# Altium schematic sheet CASSA-CPU.SchDoc(CASSA-CPU)
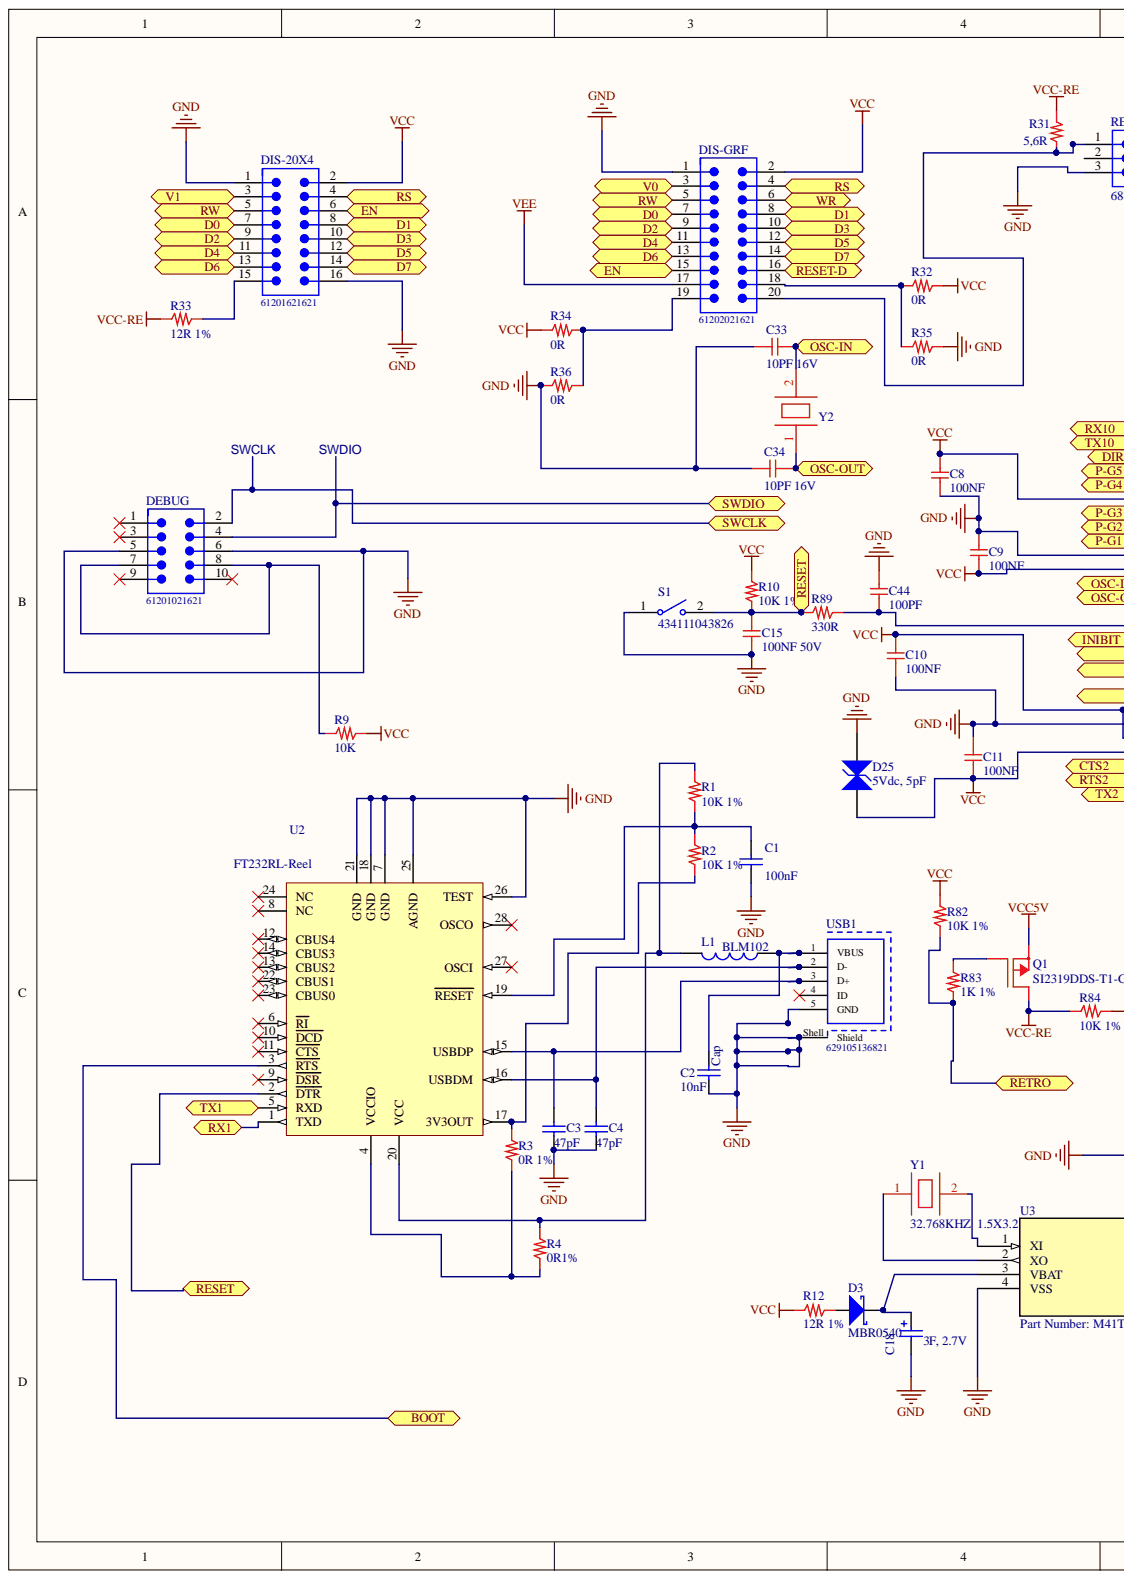
[diagram p0: CASSA-CPU.SchDoc, left]
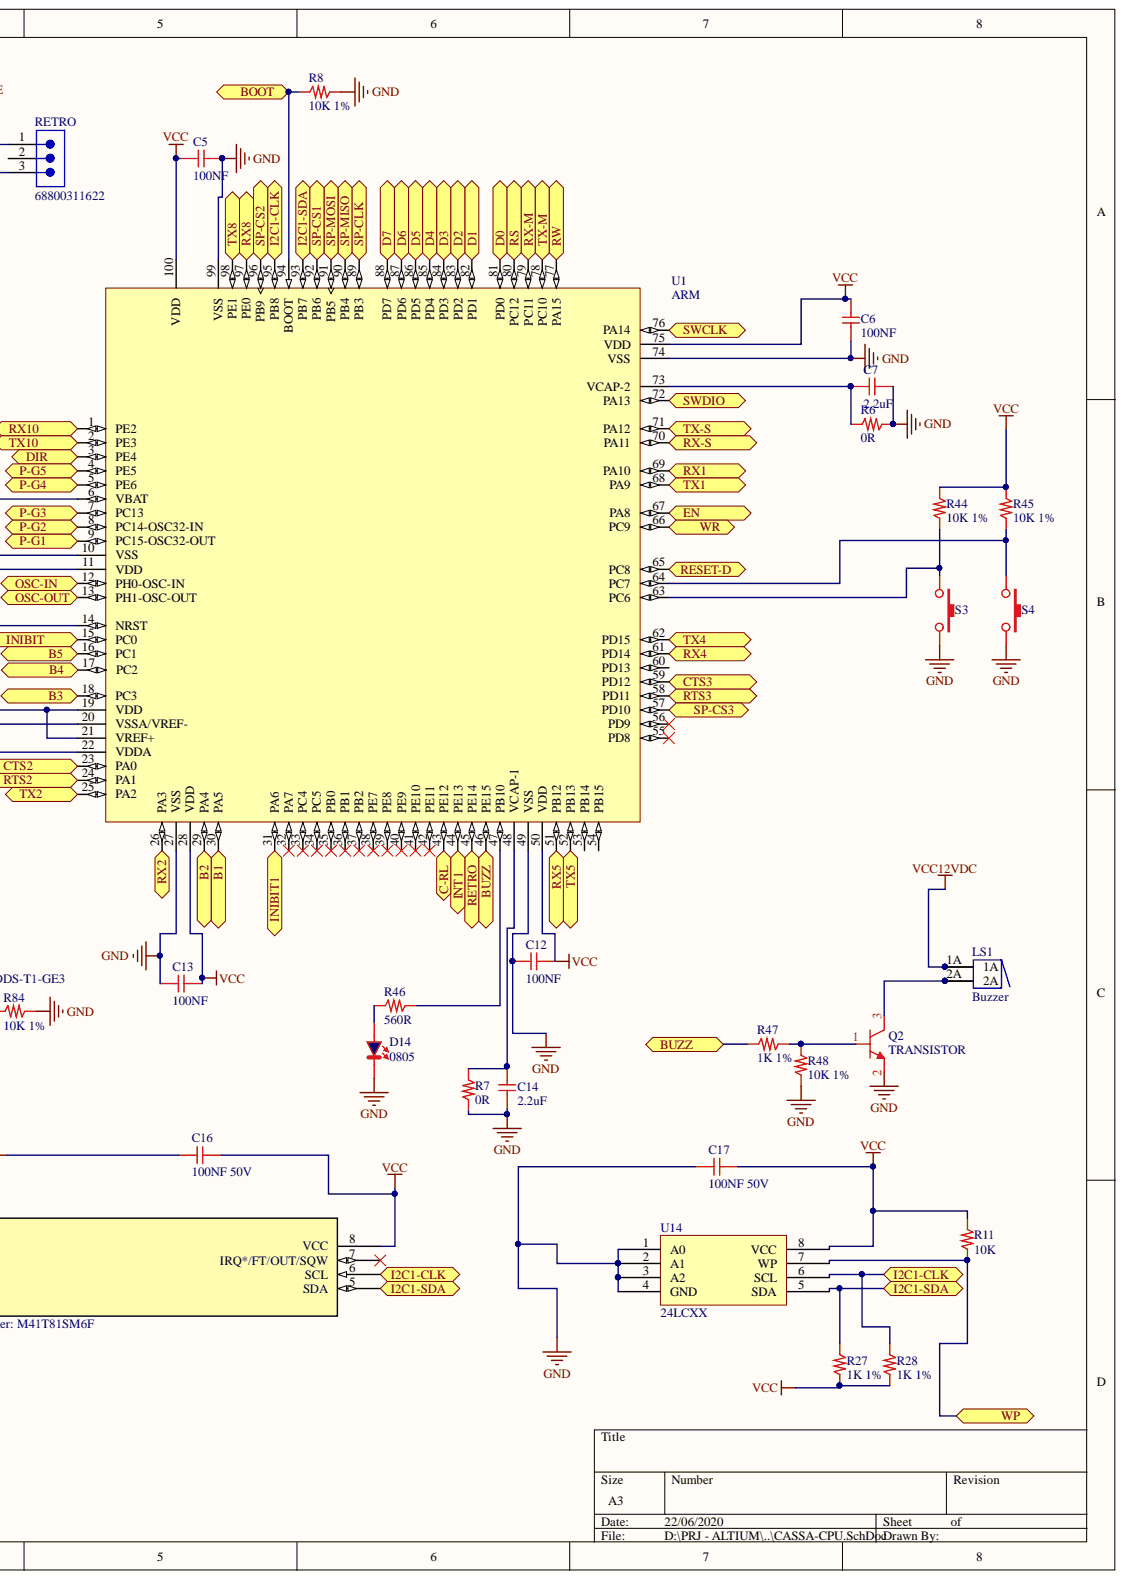
[diagram p1: CASSA-CPU.SchDoc, right]

## Parts
C1  value 100nF  [0603S_C]
C2  Cap  value 10nF  [0603S_C]
C3  value 47pF  [0603S_C]
C4  value 47pF  [0603S_C]
C5  100NF  [0402_C]
C6  100NF  [0402_C]
C7  2.2uF  [0603_c]
C8  100NF  [0402_C]
C9  100NF  [0402_C]
C10  100NF  [0402_C]
C11  100NF  [0402_C]
C12  100NF  [0402_C]
C13  100NF  [0402_C]
C14  2.2uF  [0603_c]
C15  100NF 50V  [0603_c]
C16  100NF 50V  [0603_c]
C17  100NF 50V  [0603_c]
C18  3F, 2.7V  [WCAP-STSC_8x20x3.5x0.6]
C33  10PF 16V  [0603_c]
C34  10PF 16V  [0603_c]
C44  100PF  [0402_C]
D3  MBR0540  [SOD123]
D14  0805  [0805-BLU]
D25  5Vdc, 5pF  [WE-VE_0603]
DEBUG  61201021621  [61201021621]
DIS-20X4  61201621621  [61201621621]
DIS-GRF  61202021621  [61202021621]
L1  BLM102  [WE-CBF_0805_Wide Band]
LS1  Buzzer  [BUZZER-14mm]
Q1  SI2319DDS-T1-GE3  [SOT23]
Q2  TRANSISTOR  [SOT23]
R1  10K 1%  [0603_R]
R2  10K 1%  [0603_R]
R3  0R 1%  [0603_R]
R4  0R1%  [0603_R]
R6  0R  [0402_R]
R7  0R  [0402_R]
R8  10K 1%  [0603_R]
R9  10K  [0603_R]
R10  10K 1%  [0603_R]
R11  10K  [0603_R]
R12  12R 1%  [1206_R]
R27  1K 1%  [0603_R]
R28  1K 1%  [0603_R]
R31  5,6R  [1206_R]
R32  0R  [0603_R]
R33  12R 1%  [1206_R]
R34  0R  [0603_R]
R35  0R  [0603_R]
R36  0R  [0603_R]
R44  10K 1%  [0603_R]
R45  10K 1%  [0603_R]
R46  560R  [0603_R]
R47  1K 1%  [0603_R]
R48  10K 1%  [0603_R]
R82  10K 1%  [0603_R]
R83  1K 1%  [0603_R]
R84  10K 1%  [0603_R]
R89  330R  [0603_R]
RETRO  68800311622  [68800311622]
S1  434111043826  [434111043826]
S3  PULS 6X6H6 SMD  [PULS 6x6h6 SMD]  (value not drawn)
S4  PULS 6X6H6 SMD  [PULS 6x6h6 SMD]  (value not drawn)
U1  ARM  [TQFP100_N]
U2  FT232RL-Reel  [SSOP-28_N]
U3  Part Number: M41T81SM6F  [SO-8]
U14  24LCXX  [SO8_N]
USB1  629105136821  [629105136821]
Y1  32.768KHZ  1.5X3.2  [CRIS 3.2X1.5]
Y2  8MHZ  [QUARZO-ABM3]  (value not drawn)

## Ports
B1, B2, B3, B4, B5, BOOT, BUZZ, C-RL, CTS2, CTS3, D0, D1, D2, D3, D4, D5, D6, D7, DIR, EN, I2C1-CLK, I2C1-SDA, INIBIT, INIBIT1, INT1, OSC-IN, OSC-OUT, P-G1, P-G2, P-G3, P-G4, P-G5, RESET, RESET-D, RETRO, RS, RTS2, RTS3, RW, RX1, RX2, RX4, RX5, RX8, RX10, RX-M, RX-S, SP-CLK, SP-CS1, SP-CS2, SP-CS3, SP-MISO, SP-MOSI, SWCLK, SWDIO, TX1, TX2, TX4, TX5, TX8, TX10, TX-M, TX-S, V0, V1, WP, WR

## Nets
GND: C1.1 C2.2 C3.1 C4.1 C5.2 C6.1 C7.2 C8.1 C9.2 C10.1 C11.2 C12.1 C13.1 C14.2 C15.2 C16.2 C17.1 C18.1 C33.1 C34.1 C44.2 D14.K D25.2 DEBUG.5 DEBUG.6 DIS-20X4.1 DIS-20X4.16 DIS-GRF.1 Q2.2 R6.2 R7.1 R8.2 R35.2 R36.1 R48.2 R84.1 RETRO.3 S1.1 S3.2 S4.2 U1.10(VSS) U1.20(VSSA/VREF-) U1.27(VSS) U1.49(VSS) U1.74(VSS) U1.99(VSS) U2.7(GND) U2.18(GND) U2.21(GND) U2.25(AGND) U2.26(TEST) U3.4(VSS) U14.1(A0) U14.2(A1) U14.3(A2) U14.4(GND) USB1.5(GND) USB1.Shell(Shield)
VCC: C5.1 C6.2 C8.2 C9.1 C10.2 C11.1 C12.2 C13.2 C16.1 C17.2 D25.1 DIS-20X4.2 DIS-GRF.2 R9.1 R10.2 R11.1 R12.1 R27.2 R28.2 R32.2 R34.1 R44.1 R45.1 R82.2 U1.6(VBAT) U1.11(VDD) U1.19(VDD) U1.21(VREF+) U1.22(VDDA) U1.28(VDD) U1.50(VDD) U1.75(VDD) U1.100(VDD) U3.8(VCC) U14.8(VCC)
VCC5V: Q1.2
VCC12VDC: LS1.1(1A) LS1.1A
VCC-RE: Q1.3 R31.2 R33.1 R84.2
VEE: DIS-GRF.17
B1: U1.30(PA5) ; port B1
B2: U1.29(PA4) ; port B2
B3: U1.18(PC3) ; port B3
B4: U1.17(PC2) ; port B4
B5: U1.16(PC1) ; port B5
BOOT: R8.1 U1.94(BOOT) U2.3(RTS) ; port BOOT
BUZZ: R47.1 U1.46(PE15) ; port BUZZ
C-RL: U1.43(PE12) ; port C-RL
CTS2: U1.23(PA0) ; port CTS2
CTS3: U1.59(PD12) ; port CTS3
D0: DIS-20X4.7 DIS-GRF.7 U1.81(PD0) ; port D0
D1: DIS-20X4.8 DIS-GRF.8 U1.82(PD1) ; port D1
D2: DIS-20X4.9 DIS-GRF.9 U1.83(PD2) ; port D2
D3: DIS-20X4.10 DIS-GRF.10 U1.84(PD3) ; port D3
D4: DIS-20X4.11 DIS-GRF.11 U1.85(PD4) ; port D4
D5: DIS-20X4.12 DIS-GRF.12 U1.86(PD5) ; port D5
D6: DIS-20X4.13 DIS-GRF.13 U1.87(PD6) ; port D6
D7: DIS-20X4.14 DIS-GRF.14 U1.88(PD7) ; port D7
DIR: U1.3(PE4) ; port DIR
EN: DIS-20X4.6 DIS-GRF.15 U1.67(PA8) ; port EN
I2C1-CLK: R28.1 U1.95(PB8) U3.6(SCL) U14.6(SCL) ; port I2C1-CLK
I2C1-SDA: R27.1 U1.93(PB7) U3.5(SDA) U14.5(SDA) ; port I2C1-SDA
INIBIT: U1.15(PC0) ; port INIBIT
INIBIT1: U1.31(PA6) ; port INIBIT1
INT1: U1.44(PE13) ; port INT1
OSC-IN: C33.2 U1.12(PH0-OSC-IN) Y2.2 ; port OSC-IN
OSC-OUT: C34.2 U1.13(PH1-OSC-OUT) Y2.1 ; port OSC-OUT
P-G1: U1.9(PC15-OSC32-OUT) ; port P-G1
P-G2: U1.8(PC14-OSC32-IN) ; port P-G2
P-G3: U1.7(PC13) ; port P-G3
P-G4: U1.5(PE6) ; port P-G4
P-G5: U1.4(PE5) ; port P-G5
RESET: C15.1 R10.1 R89.1 S1.2 U2.2(DTR) ; port RESET
RESET-D: DIS-GRF.16 U1.65(PC8) ; port RESET-D
RETRO: R82.1 R83.1 U1.45(PE14) ; port RETRO
RS: DIS-20X4.4 DIS-GRF.4 U1.80(PC12) ; port RS
RTS2: U1.24(PA1) ; port RTS2
RTS3: U1.58(PD11) ; port RTS3
RW: DIS-20X4.5 DIS-GRF.5 U1.77(PA15) ; port RW
RX1: U1.69(PA10) U2.1(TXD) ; port RX1
RX2: U1.26(PA3) ; port RX2
RX4: U1.61(PD14) ; port RX4
RX5: U1.51(PB12) ; port RX5
RX8: U1.97(PE0) ; port RX8
RX10: U1.1(PE2) ; port RX10
RX-M: U1.79(PC11) ; port RX-M
RX-S: U1.70(PA11) ; port RX-S
SP-CLK: U1.89(PB3) ; port SP-CLK
SP-CS1: U1.92(PB6) ; port SP-CS1
SP-CS2: U1.96(PB9) ; port SP-CS2
SP-CS3: U1.57(PD10) ; port SP-CS3
SP-MISO: U1.90(PB4) ; port SP-MISO
SP-MOSI: U1.91(PB5) ; port SP-MOSI
SWCLK: DEBUG.2 U1.76(PA14) ; port SWCLK
SWDIO: DEBUG.4 U1.72(PA13) ; port SWDIO
TX1: U1.68(PA9) U2.5(RXD) ; port TX1
TX2: U1.25(PA2) ; port TX2
TX4: U1.62(PD15) ; port TX4
TX5: U1.52(PB13) ; port TX5
TX8: U1.98(PE1) ; port TX8
TX10: U1.2(PE3) ; port TX10
TX-M: U1.78(PC10) ; port TX-M
TX-S: U1.71(PA12) ; port TX-S
V0: DIS-GRF.3 ; port V0
V1: DIS-20X4.3 ; port V1
WP: R11.2 U14.7(WP) ; port WP
WR: DIS-GRF.6 U1.66(PC9) ; port WR
NetC1_2: C1.2 R1.1 R2.2 U2.19(RESET)
NetC2_1: C2.1 L1.1 USB1.1(VBUS)
NetC3_2: C3.2 U2.15(USBDP) USB1.3(D+)
NetC4_2: C4.2 U2.16(USBDM) USB1.2(D-)
NetC7_1: C7.1 R6.1 U1.73(VCAP-2)
NetC14_1: C14.1 R7.2 U1.48(VCAP-1)
NetC18_2: C18.2 D3.2 U3.3(VBAT)
NetC44_1: C44.1 R89.2 U1.14(NRST)
NetD3_1: D3.1 R12.2
NetD14_A: D14.A R46.1
NetDEBUG_7: DEBUG.7 DEBUG.8 R9.2
NetDIS-20X4_15: DIS-20X4.15 R33.2
NetDIS-GRF_18: DIS-GRF.18 R32.1 R35.1
NetDIS-GRF_19: DIS-GRF.19 R34.2 R36.2
NetDIS-GRF_20: DIS-GRF.20 R31.1 RETRO.1
NetL1_2: L1.2 R1.2 R4.2 U2.20(VCC)
NetLS1_2: LS1.2(2A) LS1.2A Q2.3
NetQ1_1: Q1.1 R83.2
NetQ2_1: Q2.1 R47.2 R48.1
NetR2_1: R2.1 R3.2 U2.17(3V3OUT)
NetR3_1: R3.1 R4.1 U2.4(VCCIO)
NetR44_2: R44.2 S3.1 U1.63(PC6)
NetR45_2: R45.2 S4.1 U1.64(PC7)
NetR46_2: R46.2 U1.47(PB10)
NetU3_1: U3.1(XI) Y1.2
NetU3_2: U3.2(XO) Y1.1

Not connected: DEBUG 1 3 9 10; RETRO 2; U1 32(PA7) 33(PC4) 34(PC5) 35(PB0) 36(PB1) 37(PB2) 38(PE7) 39(PE8) 40(PE9) 41(PE10) 42(PE11) 53(PB14) 54(PB15) 55(PD8) 56(PD9) 60(PD13); U2 6(RI) 8(NC) 9(DSR) 10(DCD) 11(CTS) 12(CBUS4) 13(CBUS2) 14(CBUS3) 22(CBUS1) 23(CBUS0) 24(NC) 27(OSCI) 28(OSCO); U3 7(IRQ*/FT/OUT/SQW); USB1 4(ID)

## Library data of the parts
C1 = Cap: CAPACITOR-X7R-100nF; Simulation: CAP; Published: 8-Jun-2000; PackageReference: RAD-0.3; PackageDescription: Radial Cap, Thru-Hole; 2 Leads; 0.3 in Pin Spacing; Library Name: Miscellaneous Devices.IntLib; LatestRevisionNote: Re-released for DXP Platform.; LatestRevisionDate: 17-Jul-2002; Component Kind: Standard; Publisher: Altium Limited
C2 = Cap: Capacitor; Simulation: CAP; Published: 8-Jun-2000; PackageReference: RAD-0.3; PackageDescription: Radial Cap, Thru-Hole; 2 Leads; 0.3 in Pin Spacing; Library Name: Miscellaneous Devices.IntLib; LatestRevisionNote: Re-released for DXP Platform.; LatestRevisionDate: 17-Jul-2002; Component Kind: Standard; Publisher: Altium Limited
C3 C4 = Cap: CAPACITOR-X7R-47P; Simulation: CAP; Published: 8-Jun-2000; PackageReference: RAD-0.3; PackageDescription: Radial Cap, Thru-Hole; 2 Leads; 0.3 in Pin Spacing; Library Name: Miscellaneous Devices.IntLib; LatestRevisionNote: Re-released for DXP Platform.; LatestRevisionDate: 17-Jul-2002; Component Kind: Standard; Publisher: Altium Limited
C5 C6 C8-C13 = 100NF 16V: Cap X7R 100NF 16V; Produttore: ??; Cod. Interno: COCS556561600104; Library Name: CapSMD0402.SchLib; Component Kind: Standard; Cod. Produttore: ??
C7 C14 = 2.2uF 10V: 2.2 µF, 10 V, ± 10%, X5R 0603; Produttore: WALSIN; Costo 1000: 0,0183; Costo 100: 0,0436; Cod. Produttore: 0603X225K100CT; Cod. Interno: COCS??; Library Name: CapSMD0603.SchLib; ComponentLink1URL: http://it.farnell.com/walsin/0603x225k100ct/cond-mlcc-x5r-2-2uf-10v-0603-bob/dp/2495422?st=2.2uf; ComponentLink1Description: FARNELL; Component Kind: Standard
C15-C17 = 100NF 50V: Cap X7R 100nF 50V Flex Terminal; Produttore: Samsung; Cod. Produttore: CL10B104KB8SFNC; Cod. Interno: COCS50665050104; Library Name: CapSMD0603.SchLib; Component Kind: Standard; Cod. DigiKey: 1276-1936-2-ND
C18 = 850617030001: WCAP-STSC Super Capacitors, 8x20mm, 3F, 2.7V; RESR DC: 70mOhm; RESR AC: 60mOhm; Rated Voltage: 2.7VDC; Rated Discharge Current: 0.7A; Power Density: 18.08kW/kg; Pitch: 3.5mm; Max. Discharge Current: 3.3A; Leakage Current: 0.008mA; Energy Density: 2.1Wh/kg; Endurance: 500000 Cycles; Published Date: August 2019; Part Number: 850617030001; Packaging: Bag and Carton; Operating Temperature: -40 to +65degC; Mounting Technology: THT; Library Name: WCAP-STSC.SchLib; Height: 20mm +/-1.5mm; Diameter: 8mm; ComponentLink2URL: https://katalog.we-online.com/pbs/datasheet/850617030001.pdf; ComponentLink2Description: Datasheet; ComponentLink1URL: http://www.we-online.de; ComponentLink1Description: Manufacturer; Component Kind: Standard; Capacitance: 3F; Publisher: Wurth Elektronik
C33 C34 = 10PF 16V: Cer COG 10PF 16V; Produttore: ??; Cod. Interno: COCS 506655000120; Library Name: CapSMD0603.SchLib; Component Kind: Standard; Cod. Produttore: ??
C44 = 100NF 16V: Cap X7R 100PF 16V; Produttore: ??; Cod. Interno: COCS556561600104; Library Name: CapSMD0402.SchLib; Component Kind: Standard; Cod. Produttore: ??
D3 = MBR0540: Schottky Diode; Simulation: SKYDIODE; Published: 8-Jun-2000; PackageReference: SMB; PackageDescription: DO-214-AA/SMB; 2 C-Bend Leads; Body 5.3 x 3.6 mm, inc. leads (LxW); LatestRevisionNote: Re-released for DXP Platform.; LatestRevisionDate: 17-Jul-2002; Component Kind: Standard; Code_JEDEC: DO-214-AA; Publisher: Altium Limited; Library Name: HSW.SchLib
D14 = LED BLU 0805: Vf(typ): 3.3V; Produttore: kingbright; Luminosità: 100mcd 20mA; Cod. Produttore: KPTR-3216QBC-D; Description: LED BLU 0805; Component Kind: Standard; Library Name: DiodiLED.SchLib
D25 = 82356050050: WE-VE ESD Suppressor, size 0603, 5Vdc, 5pF; Leakage Current: 1uA; ESD Voltage air discharge: 15kV; Clamp Voltage: 75V; Working Voltage: 5Vdc; Size: 0603; Published Date: June 2019; Part Number: 82356050050; Packaging: Tape and Reel; Operating Temperature: -40 to +85degC; Mounting Technology: SMT; Library Name: WE-VE_0603.SchLib; ComponentLink2URL: https://katalog.we-online.com/pbs/datasheet/82356050050.pdf; ComponentLink2Description: Datasheet; ComponentLink1URL: http://www.we-online.de; ComponentLink1Description: Manufacturer; Component Kind: Standard; Capacitance: 5pF; Publisher: Wurth Elektronik
DEBUG = 61201021621: Male Box Header WR-BHD, THT, Vertical, pitch 2.54 mm, 10 pins; Working Voltage: 250 VAC; Withstanding Voltage: 500 VAC; Pitch: 2.54 mm; Pin Number: 10; Contact Resistance: 20 mOhm; Published Date: August 2018; Part Number: 61201021621; Packaging: Tray; Orientation: Vertical; Operating Temperature: -40 to 125 degC; Mounting Technology: THT; Gender: Male; ComponentLink2URL: https://katalog.we-online.de/em/datasheet/6120xx21621.pdf; ComponentLink2Description: Datasheet; ComponentLink1URL: http://www.we-online.de; ComponentLink1Description: Manufacturer; Component Kind: Standard; Publisher: Wurth Elektronik; Library Name: CONN-FLAT.SchLib
DIS-20X4 = 61201621621: Male Box Header WR-BHD, THT, Vertical, pitch 2.54 mm, 16 pins; Working Voltage: 250 VAC; Withstanding Voltage: 500 VAC; Pitch: 2.54 mm; Pin Number: 16; Contact Resistance: 20 mOhm; Published Date: August 2018; Part Number: 61201621621; Packaging: Tray; Orientation: Vertical; Operating Temperature: -40 to 125 degC; Mounting Technology: THT; Gender: Male; ComponentLink2URL: https://katalog.we-online.de/em/datasheet/6120xx21621.pdf; ComponentLink2Description: Datasheet; ComponentLink1URL: http://www.we-online.de; ComponentLink1Description: Manufacturer; Component Kind: Standard; Publisher: Wurth Elektronik; Library Name: CONN-FLAT.SchLib
DIS-GRF = 61202021621: Male Box Header WR-BHD, THT, Vertical, pitch 2.54 mm, 20 pins; Working Voltage: 250 VAC; Withstanding Voltage: 500 VAC; Pitch: 2.54 mm; Pin Number: 20; Contact Resistance: 20 mOhm; Published Date: August 2018; Part Number: 61202021621; Packaging: Tray; Orientation: Vertical; Operating Temperature: -40 to 125 degC; Mounting Technology: THT; Gender: Male; ComponentLink2URL: https://katalog.we-online.de/em/datasheet/6120xx21621.pdf; ComponentLink2Description: Datasheet; ComponentLink1URL: http://www.we-online.de; ComponentLink1Description: Manufacturer; Component Kind: Standard; Publisher: Wurth Elektronik; Library Name: CONN-FLAT.SchLib
L1 = WE-CBF, 74279205: WE-CBF SMD EMI Suppression Ferrite Bead, 0805, 1000Ω; Type: Wide Band; Value: 1000Ω; Size: 0805; PublishedDate: Aug 2016; PartNumber: 74279205; Library Name: WE-CBF_0805.SchLib; ComponentLink2URL: http://katalog.we-online.de/pbs/datasheet/74279205.pdf; ComponentLink2Description: Datasheet; ComponentLink1URL: http://www.we-online.de; ComponentLink1Description: Manufacturer; Component Kind: Standard; Publisher: Wurth Elektronik
LS1 = Buzzer: Magnetic Transducer Buzzer; Published: 24-Mar-1999; PackageReference: ABSM-1574; PackageDescription: Magnetic Transducer Buzzer; LatestRevisionNote: Schematic revised, PCB Footprint replaced.; LatestRevisionDate: 17-Dec-2003; DatasheetVersion: 17-Dec-2003; ComponentLink1URL: http://www.megastar.com/linecard/buzzmode/index.php; ComponentLink1Description: Manufacturer Link; Component Kind: Standard; Publisher: Altium Limited; Library Name: HSW.SchLib
Q1 = SI2319DDS-T1-GE3: P-Channel Enhancement Mode Field-Effect Transistor; Produttore Nome: Farchild; Produttore Codice: BSS84; DigiKey Costo: 0.044 € (100 pz); DigiKey Codice: BSS84CT-ND; Component Kind: Standard; Library Name: HSW.SchLib
Q2 = BC847B: NPN Silicon Darlington Transistors; Vce: 30V; Vcb: 40V; Produttore: INFINEON; Ic: 500mA; Cod. Produttore: BCV27; Cod. Interno: TRXS ??; Component Kind: Standard; Library Name: HSW.SchLib
R1-R4 R27 R28 R47 R83 = 1K 1%: Res Film Spesso 1/16W 1K 1%; Produttore: ??; Cod. Interno: RESS4166356B0102; Library Name: ResSMD0603.SchLib; Component Kind: Standard; Cod. Produttore: ??
R6 R7 = 0R: Res Film Spesso 1/16W 0R 5%; Produttore: ??; Cod. Interno: RESS4165560C0000; Library Name: ResSMD0402.SchLib; Component Kind: Standard; Cod. Produttore: ??
R8 R10 R44 R45 R48 R82 R84 = 10K 1%: Res Film Spesso 1/16W 10K 1%; Produttore: Panasonic; Cod. Produttore: ERJ-3EKF1002V; Cod. Interno: RESS4166356B0103; Library Name: ResSMD0603.SchLib; Component Kind: Standard
R9 R11 = 10K: Res Film Spesso 1/16W 10K 5%; Produttore: ??; Cod. Interno: RESS4166556B0103??; Library Name: ResSMD0603.SchLib; Component Kind: Standard; Cod. Produttore: ??
R12 R33 = 12R 1%: 12R 1% 1206 1/4W; Produttore: Yageo; Cod. Produttore: RC1206FR-0712RL; Library Name: ResSMD1206.SchLib; Component Kind: Standard; Cod. DigiKey: 311-12.0FRCT-ND; Case: 1206
R31 = 5,6R: 5,6R 1206 1/4W; Cod. Interno: RESS 4173525B03R3; Library Name: ResSMD1206.SchLib; Component Kind: Standard; Case: 1206
R32 R34-R36 = 0R: Res Film Spesso 1/16W 0R 5%; Cod. Interno: RESS 4166556B0000; Library Name: ResSMD0603.SchLib; Component Kind: Standard
R46 = 560R: Res Film Spesso 1/16W 560R 5%; Cod. Interno: RESS 4166556B0561; Library Name: ResSMD0603.SchLib; Component Kind: Standard
R89 = 330R: Res Film Spesso 1/16W 330R 5%; Cod. Interno: RESS4166556B0331; Library Name: ResSMD0603.SchLib; Component Kind: Standard
RETRO = 68800311622: WR-WTB “J” Type THT Vertical Male Shrouded Header, pitch 2.5mm, 3p; Working Voltage: 250VAC; Withstanding Voltage: 800VAC; Rated Current: 3A; Pin Number: 3; Contact Resistance: 20mOhm; Type: Vertical; Published Date: January 2020; Pitch: 2.5mm; Part Number: 68800311622; Packaging: Bag; Operating Temperature: -25 to +85degC; Mounting Technology: THT; Library Name: WR-WTB_2.50mm.SchLib; Gender: Male; ComponentLink2URL: https://www.we-online.com/catalog/datasheet/68800311622.pdf; ComponentLink2Description: Datasheet; ComponentLink1URL: http://www.we-online.de; ComponentLink1Description: Manufacturer; Component Kind: Standard; Publisher: Wurth Elektronik
S1 = 434111043826: WS-TASV SMT Tact Switch 6x3.5mm, height 4.3mm, 260gf; Washable: No; Rated Voltage: 12VDC; Rated Current: 50mA; Terminal Plating: Silver; Terminal Material: Copper Alloy; Published Date: September 2019; Part Number: 434111043826; Packaging: Tape and Reel; Operation Force: 260gf; Operating Temperature: -40 to +85degC; Mounting Technology: SMT; Library Name: WS-TASV.SchLib; Height: 4.3mm; Contact Plating: Silver; Contact Material: Stainless Steel; ComponentLink2URL: https://katalog.we-online.com/em/datasheet/434111043826.pdf; ComponentLink2Description: Datasheet; ComponentLink1URL: http://www.we-online.de; ComponentLink1Description: Manufacturer; Component Kind: Standard; Publisher: Wurth Elektronik
S3 S4 = PULS 6X6H6 SMD: Tactile Switch 4Pin 6x6mm  H6; Cod. Produttore: TL3301AF260QG; Cod. Interno: INT 15TL3301AF260QG; Produttore: E Switch; Library Name: SWITCH.SCHLIB; Component Kind: Standard; Cod. Mouser: 612-TL3301AF2
U1 = STM32F413VGT3TR _1: 32-Bit arm st; Published: 24-Aug-2001; PCB3D: IMST425-PQFP100; PackageVersion: Jun-2003; PackageReference: PQFP100; PackageDescription: 100-Pin Plastic Quad Flat Pack Rectangular 0.65 mm Pitch; LatestRevisionNote: IPC-7351 Footprint Added.; LatestRevisionDate: 13-Apr-2006; DatasheetVersion: Feb-1996; ComponentLink1URL: http://www.st.com/; ComponentLink1Description: Manufacturer Link; Component Kind: Standard; Code_IPC: QFP65P1720X2320-100; Publisher: Altium Limited; Library Name: HSW.SchLib
U2 = FT232RL-Reel: USB UART Asynchronous Serial Data Transfer Chip, SSOP-28, Tape and Reel; Published: 19-Aug-2010; PackageVersion: 2010; PackageReference: SSOP-28; PackageDescription: 28-Pin Small Outline Package 0.65 mm Pitch; DatasheetVersion: ver 2.07, Jul-2010; ComponentLink2URL: http://www.ftdichip.com/Support/Documents/DataSheets/ICs/DS_FT232R.pdf; ComponentLink2Description: Datasheet; ComponentLink1URL: http://www.ftdichip.com/Products/ICs/FT232R.htm; ComponentLink1Description: Manufacturer Link; Component Kind: Standard; Publisher: Altium Limited; Library Name: POLMAC-WIFI.SCHLIB
U3 = M41T81SM6F: No Description Available; Vendor: STMicroelectronics; Built_By: EMA_UL_Team; Value: Value; Type: DEV; RefDes: RefDes; Manufacturer_Part_Number: M41T81SM6F; Library Name: M41T81.SchLib; Component Kind: Standard; Copyright: Copyright (C) 2018 Accelerated Designs. All rights reserved
USB1 = 629105136821: Micro USB 2.0 Type B Receptacle WR-COM, Horizontal, SMT, with Pads and Pegs; Working Voltage: 30 VAC; Rated Current: 1 A; Contact Resistance: 30 mOhm; Published Date: September 2018; Part Number: 629105136821; Packaging: Tape and Reel; Orientation: Horizontal; Operating Temperature: -40 to 105 degC; Mounting Technology: SMT; Library Name: USB Connectors WR-COM.SchLib; Gender: Receptacle; Connector Type: Micro USB 2.0 Type B; ComponentLink2URL: https://katalog.we-online.de/em/datasheet/629105136821.pdf; ComponentLink2Description: Datasheet; ComponentLink1URL: http://www.we-online.de; ComponentLink1Description: Manufacturer; Component Kind: Standard; Publisher: Wurth Elektronik
Y1 = QUARZO 32.768KHZ  1.5X3.2: QUARZO SMD 32.768KHZ 1.5X3.2; Produttore: TXC; Costo 1000: 0.301; Costo 100: 0.326; Cod. Produttore: 9HT10-32.768KDZB-T; Cod. Interno: ??; Library Name: OSC.SchLib; Component Kind: Standard; Cod. Farnell: 2819301
Y2 = QUARZO-ABM3-8MHZ: QUARZO SMD 8MHZ ABM3; Produttore: TXC; Costo 1000: 0.301; Costo 100: 0.326; Cod. Produttore: 9HT10-32.768KDZB-T; Cod. Interno: ??; Component Kind: Standard; Cod. Farnell: 2819301; Library Name: HSW.SchLib
U14 = 24LCXX: Component Kind: Standard; Library Name: HSW.SchLib
